# Altium schematic sheet ezfet.SchDoc
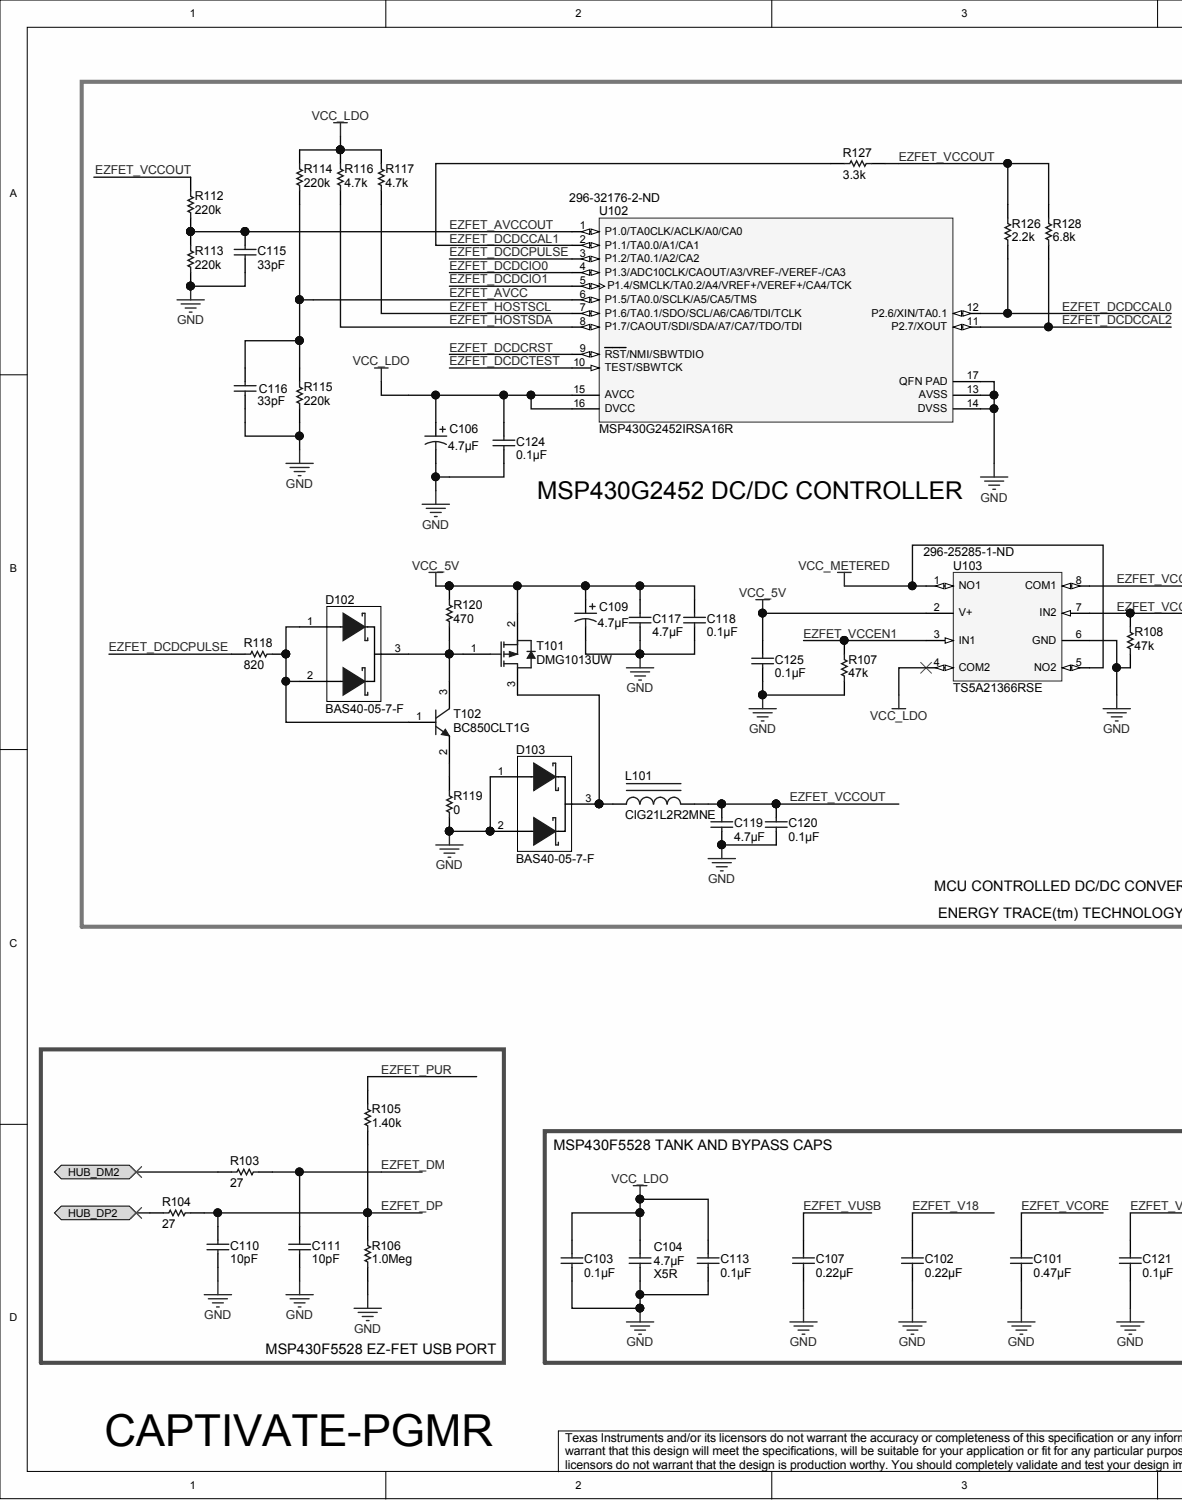
[diagram p0: ezfet.SchDoc, left]
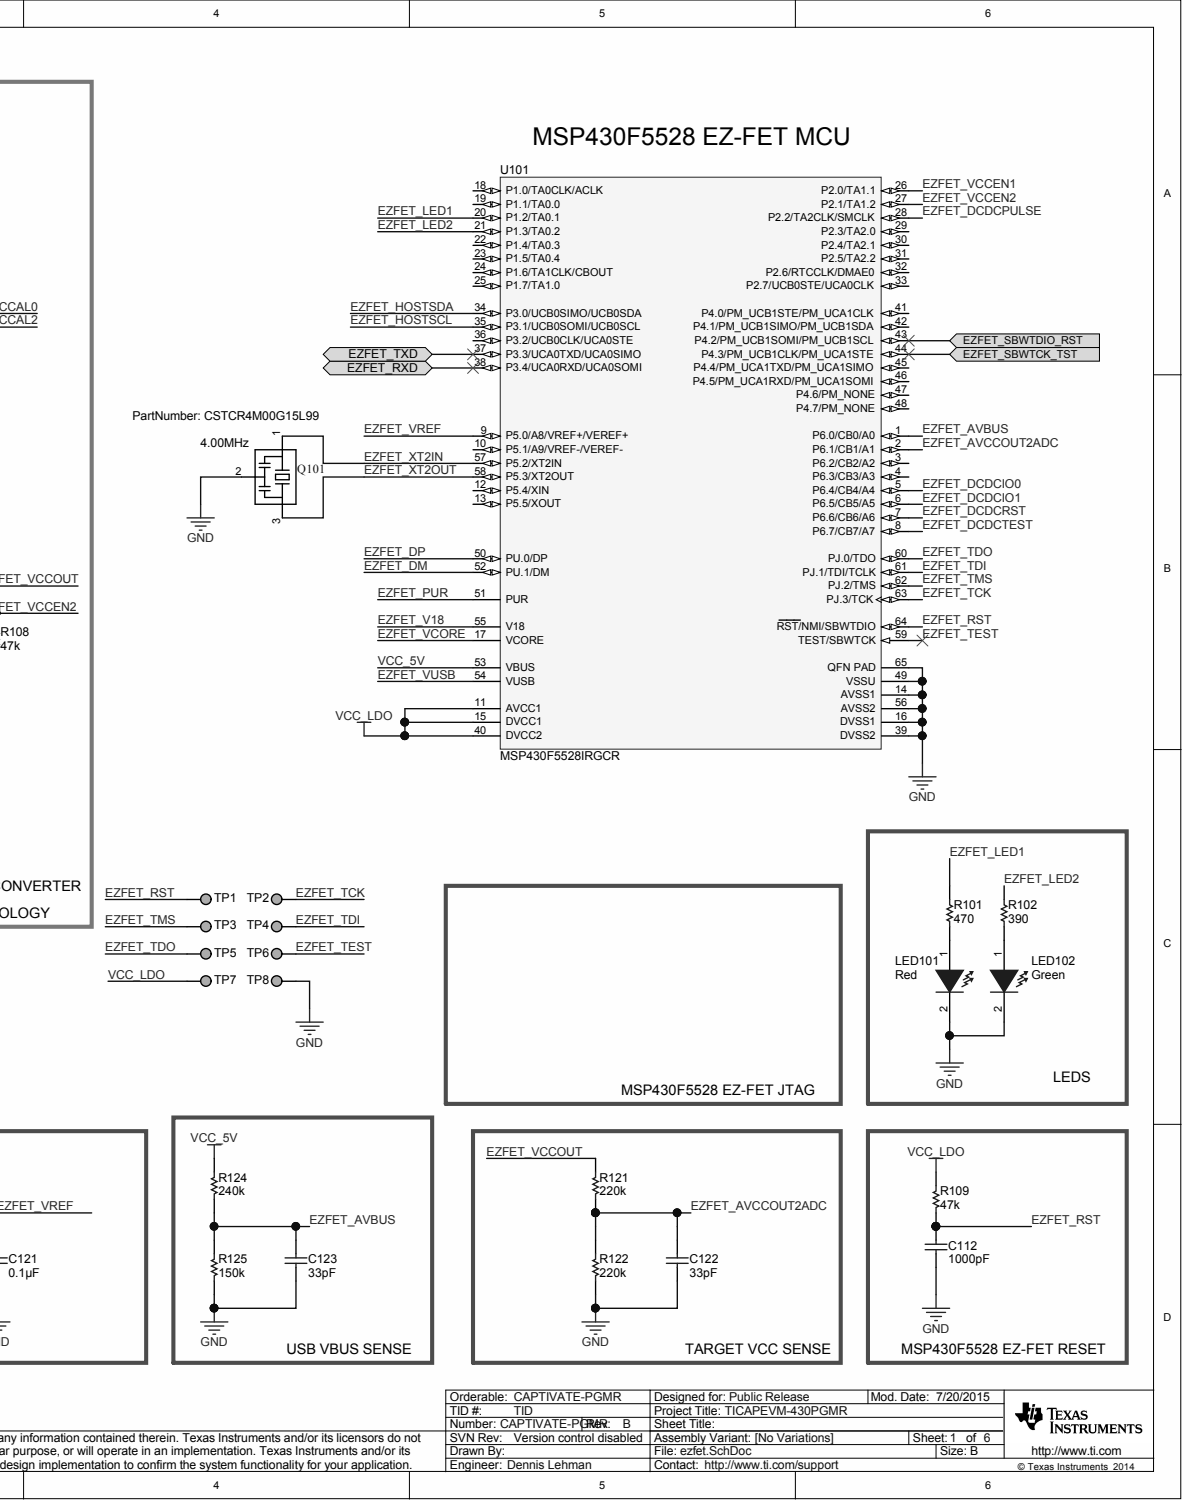
[diagram p1: ezfet.SchDoc, right]

## Parts
C101  ValueDisplayed: 0.47µF  [0402]  (hidden comment: GRM155R61A474KE15D)
C102  ValueDisplayed: 0.22µF  [0402]  (hidden comment: GRM155R61A224KE19D)
C103  ValueDisplayed: 0.1µF  [0402]  (hidden comment: C1005X5R1A104K)
C104  TempCo: X5R  ValueDisplayed: 4.7µF  [0603]  (hidden comment: CGB3B1X5R1A475K055AC)
C106  ValueDisplayed: 4.7µF  [3216-18]  (hidden comment: 293D475X9010A2TE3)
C107  ValueDisplayed: 0.22µF  [0402]  (hidden comment: GRM155R61A224KE19D)
C109  ValueDisplayed: 4.7µF  [3216-18]  (hidden comment: 293D475X9010A2TE3)
C110  value 10pF  [0402]  (hidden comment: 500R07S100JV4T)
C111  value 10pF  [0402]  (hidden comment: 500R07S100JV4T)
C112  value 1000pF  [0402]  (hidden comment: C1005X7R1H102K)
C113  ValueDisplayed: 0.1µF  [0402]  (hidden comment: C1005X5R1A104K)
C115  value 33pF  [0402]  (hidden comment: GRM1555C1H330JA01D)
C116  value 33pF  [0402]  (hidden comment: GRM1555C1H330JA01D)
C117  ValueDisplayed: 4.7µF  [0603]  (hidden comment: 0603ZD475KAT2A)
C118  ValueDisplayed: 0.1µF  [0402]  (hidden comment: C1005X5R1A104K)
C119  ValueDisplayed: 4.7µF  [0603]  (hidden comment: 0603ZD475KAT2A)
C120  ValueDisplayed: 0.1µF  [0402]  (hidden comment: C1005X5R1A104K)
C121  ValueDisplayed: 0.1µF  [0402]  (hidden comment: C1005X5R1A104K)
C122  value 33pF  [0402]  (hidden comment: GRM1555C1H330JA01D)
C123  value 33pF  [0402]  (hidden comment: GRM1555C1H330JA01D)
C124  ValueDisplayed: 0.1µF  [0402]  (hidden comment: C1005X5R1A104K)
C125  ValueDisplayed: 0.1µF  [0402]  (hidden comment: C1005X5R1A104K)
D102  BAS40-05-7-F  [SOT-23]
D103  BAS40-05-7-F  [SOT-23]
L101  CIG21L2R2MNE  [0805_HV]
LED101  value Red  [LED_LTST-C190_Red]  (hidden comment: LTST-C190CKT)
LED102  value Green  [LED_LTST-C190]  (hidden comment: LTST-C190GKT)
Q101  PartNumber: CSTCR4M00G15L99  Value Displayed: 4.00MHz  [CSTCR CERAMIC RESONATOR - MURATA]  (hidden comment: MURATA-FILTER_CSTCR4M00G15)
R101  value 470  [0402]  (hidden comment: CRCW0402470RJNED)
R102  value 390  [0402]  (hidden comment: CRCW0402390RJNED)
R103  value 27  [0402]  (hidden comment: CRCW040227R0JNED)
R104  value 27  [0402]  (hidden comment: CRCW040227R0JNED)
R105  value 1.40k  [0402]  (hidden comment: CRCW04021K40FKED)
R106  value 1.0Meg  [0402]  (hidden comment: CRCW04021M00JNED)
R107  value 47k  [0402]  (hidden comment: CRCW040247K0JNED)
R108  value 47k  [0402]  (hidden comment: CRCW040247K0JNED)
R109  value 47k  [0402]  (hidden comment: CRCW040247K0JNED)
R112  value 220k  [0402]  (hidden comment: CRCW0402220KJNED)
R113  value 220k  [0402]  (hidden comment: CRCW0402220KJNED)
R114  value 220k  [0402]  (hidden comment: CRCW0402220KJNED)
R115  value 220k  [0402]  (hidden comment: CRCW0402220KJNED)
R116  value 4.7k  [0402]  (hidden comment: CRCW04024K70JNED)
R117  value 4.7k  [0402]  (hidden comment: CRCW04024K70JNED)
R118  value 820  [0402]  (hidden comment: CRCW0402820RJNED)
R119  value 0  [0402]  (hidden comment: CRCW04020000Z0ED)
R120  value 470  [0402]  (hidden comment: CRCW0402470RJNED)
R121  value 220k  [0402]  (hidden comment: CRCW0402220KJNED)
R122  value 220k  [0402]  (hidden comment: CRCW0402220KJNED)
R124  value 240k  [0402]  (hidden comment: CRCW0402240KJNED)
R125  value 150k  [0402]  (hidden comment: CRCW0402150KFKED)
R126  value 2.2k  [0402]  (hidden comment: CRCW04022K20JNED)
R127  value 3.3k  [0402]  (hidden comment: CRCW04023K30JNED)
R128  value 6.8k  [0402]  (hidden comment: CRCW04026K80JNED)
T101  DMG1013UW  [SOT-323]
T102  BC850CLT1G  [SOT-23]
TP1  TESTPOINT  [TP_VIA_060RND040]  (value not drawn)  (no BOM)
TP2  TESTPOINT  [TP_VIA_060RND040]  (value not drawn)  (no BOM)
TP3  TESTPOINT  [TP_VIA_060RND040]  (value not drawn)  (no BOM)
TP4  TESTPOINT  [TP_VIA_060RND040]  (value not drawn)  (no BOM)
TP5  TESTPOINT  [TP_VIA_060RND040]  (value not drawn)  (no BOM)
TP6  TESTPOINT  [TP_VIA_060RND040]  (value not drawn)  (no BOM)
TP7  TESTPOINT  [TP_VIA_060RND040]  (value not drawn)  (no BOM)
TP8  TESTPOINT  [TP_VIA_060RND040]  (value not drawn)  (no BOM)
U101  MSP430F5528IRGCR  [RGC0064B]
U102  MSP430G2452IRSA16R  Supplier Part Number 1: 296-32176-2-ND  [RSA0016B]
U103  TS5A21366RSE  Supplier Part Number 1: 296-25285-1-ND  [RSE0008A]

## Ports
EZFET_RXD, EZFET_SBWTCK_TST, EZFET_SBWTDIO_RST, EZFET_TXD, HUB_DM2, HUB_DP2

## Nets
GND: C101.2 C102.2 C103.2 C104.2 C106.2 C107.2 C109.2 C110.2 C111.2 C112.2 C113.2 C115.2 C116.2 C117.2 C118.2 C119.2 C120.2 C121.2 C122.2 C123.2 C124.2 C125.2 D103.1 D103.2 LED101.2 LED102.2 Q101.2 R106.2 R107.1 R108.1 R113.1 R115.1 R119.2 R122.1 R125.1 TP8.1 U101.14(AVSS1) U101.16(DVSS1) U101.39(DVSS2) U101.49(VSSU) U101.56(AVSS2) U101.65(QFN_PAD) U102.13(AVSS) U102.14(DVSS) U102.17(QFN_PAD) U103.6(GND)
VCC_METERED: U103.1(NO1) U103.5(NO2)
EZFET_AVBUS: C123.1 R124.1 R125.2 U101.1(P6.0/CB0/A0)
EZFET_AVCC: C116.1 R114.1 R115.2 U102.6(P1.5/TA0.0/SCLK/A5/CA5/TMS)
EZFET_AVCCOUT: C115.1 R112.1 R113.2 U102.1(P1.0/TA0CLK/ACLK/A0/CA0)
EZFET_AVCCOUT2ADC: C122.1 R121.1 R122.2 U101.2(P6.1/CB1/A1)
EZFET_DCDCCAL0: R126.1 U102.12(P2.6/XIN/TA0.1)
EZFET_DCDCCAL1: R127.1 U102.2(P1.1/TA0.0/A1/CA1)
EZFET_DCDCCAL2: R128.1 U102.11(P2.7/XOUT)
EZFET_DCDCIO0: U101.5(P6.4/CB4/A4) U102.4(P1.3/ADC10CLK/CAOUT/A3/VREF-/VEREF-/CA3)
EZFET_DCDCIO1: U101.6(P6.5/CB5/A5) U102.5(P1.4/SMCLK/TA0.2/A4/VREF+/VEREF+/CA4/TCK)
EZFET_DCDCPULSE: R118.2 U101.28(P2.2/TA2CLK/SMCLK) U102.3(P1.2/TA0.1/A2/CA2)
EZFET_DCDCRST: U101.7(P6.6/CB6/A6) U102.9(RST/NMI/SBWTDIO)
EZFET_DCDCTEST: U101.8(P6.7/CB7/A7) U102.10(TEST/SBWTCK)
EZFET_DM: C111.1 R103.2 U101.52(PU.1/DM)
EZFET_DP: C110.1 R104.2 R105.2 R106.1 U101.50(PU.0/DP)
EZFET_HOSTSCL: R117.1 U101.35(P3.1/UCB0SOMI/UCB0SCL) U102.7(P1.6/TA0.1/SDO/SCL/A6/CA6/TDI/TCLK)
EZFET_HOSTSDA: R116.1 U101.34(P3.0/UCB0SIMO/UCB0SDA) U102.8(P1.7/CAOUT/SDI/SDA/A7/CA7/TDO/TDI)
EZFET_LED1: R101.2 U101.20(P1.2/TA0.1)
EZFET_LED2: R102.2 U101.21(P1.3/TA0.2)
EZFET_PUR: R105.1 U101.51(PUR)
EZFET_RST: C112.1 R109.1 TP1.1 U101.64(RST/NMI/SBWTDIO)
EZFET_RXD: U101.38(P3.4/UCA0RXD/UCA0SOMI) ; port EZFET_RXD
EZFET_SBWTCK_TST: U101.44(P4.3/PM_UCB1CLK/PM_UCA1STE) ; port EZFET_SBWTCK_TST
EZFET_SBWTDIO_RST: U101.43(P4.2/PM_UCB1SOMI/PM_UCB1SCL) ; port EZFET_SBWTDIO_RST
EZFET_TCK: TP2.1 U101.63(PJ.3/TCK)
EZFET_TDI: TP4.1 U101.61(PJ.1/TDI/TCLK)
EZFET_TDO: TP5.1 U101.60(PJ.0/TDO)
EZFET_TEST: TP6.1 U101.59(TEST/SBWTCK)
EZFET_TMS: TP3.1 U101.62(PJ.2/TMS)
EZFET_TXD: U101.37(P3.3/UCA0TXD/UCA0SIMO) ; port EZFET_TXD
EZFET_V18: C102.1 U101.55(V18)
EZFET_VCCEN1: R107.2 U101.26(P2.0/TA1.1) U103.3(IN1)
EZFET_VCCEN2: R108.2 U101.27(P2.1/TA1.2) U103.7(IN2)
EZFET_VCCOUT: C119.1 C120.1 L101.2 R112.2 R121.2 R126.2 R127.2 R128.2 U103.8(COM1)
EZFET_VCORE: C101.1 U101.17(VCORE)
EZFET_VREF: C121.1 U101.9(P5.0/A8/VREF+/VEREF+)
EZFET_VUSB: C107.1 U101.54(VUSB)
EZFET_XT2IN: Q101.1 U101.57(P5.2/XT2IN)
EZFET_XT2OUT: Q101.3 U101.58(P5.3/XT2OUT)
HUB_DM2: R103.1 ; port HUB_DM2
HUB_DP2: R104.1 ; port HUB_DP2
VCC_5V: C109.1 C117.1 C118.1 C125.1 R120.1 R124.2 T101.2 U101.53(VBUS) U103.2(V+)
VCC_LDO: C103.1 C104.1 C106.1 C113.1 C124.1 R109.2 R114.2 R116.2 R117.2 TP7.1 U101.11(AVCC1) U101.15(DVCC1) U101.40(DVCC2) U102.15(AVCC) U102.16(DVCC) U103.4(COM2)
NetD102_1: D102.1 D102.2 R118.1 T102.1
NetD102_3: D102.3 R120.2 T101.1 T102.3
NetD103_3: D103.3 L101.1 T101.3
NetLED101_1: LED101.1 R101.1
NetLED102_1: LED102.1 R102.1
NetR119_1: R119.1 T102.2

Not connected: U101 3(P6.2/CB2/A2) 4(P6.3/CB3/A3) 10(P5.1/A9/VREF-/VEREF-) 12(P5.4/XIN) 13(P5.5/XOUT) 18(P1.0/TA0CLK/ACLK) 19(P1.1/TA0.0) 22(P1.4/TA0.3) 23(P1.5/TA0.4) 24(P1.6/TA1CLK/CBOUT) 25(P1.7/TA1.0) 29(P2.3/TA2.0) 30(P2.4/TA2.1) 31(P2.5/TA2.2) 32(P2.6/RTCCLK/DMAE0) 33(P2.7/UCB0STE/UCA0CLK) 36(P3.2/UCB0CLK/UCA0STE) 41(P4.0/PM_UCB1STE/PM_UCA1CLK) 42(P4.1/PM_UCB1SIMO/PM_UCB1SDA) 45(P4.4/PM_UCA1TXD/PM_UCA1SIMO) 46(P4.5/PM_UCA1RXD/PM_UCA1SOMI) 47(P4.6/PM_NONE) 48(P4.7/PM_NONE)

## Library data of the parts
C101 = GRM155R61A474KE15D: CAP, CERM, 0.47uF, 10V, +/-10%, X5R, 0402; Manufacturer: MuRata; Cap_F: 0.00000047; CompType: Capacitor; Polarized: N; TaMax_C: 85; TaMin_C: -55; Tolerance_%: 10; U/M: EA; Value1: Unknown; Cap_Case: 0402; Component Kind: Standard; ComponentLink1Description: Datasheet link; ComponentLink1URL: http://search.murata.co.jp/Ceramy/PnsearchViewAction.do?HnOFG=ON&HnNFG=ON&SEL_CNT=50&sLang=en&sKey=GRM155R61A474KE15D&x=9&y=9&search=startwith; LatestRevisionDate: 2013-09-06; Library Name: Capacitor_Ceramic.IntLib; MountType: SMT; PackageReference: 0402; PartNumber: GRM155R61A474KE15D; Published: 2008-06-19; RoHS: Y; Signal Integrity: Cap; Simulation: Cap; Supplier 1: Digi-Key; Supplier 2: Mouser; Supplier 3: Farnell; Supplier Part Number 1: 490-3264-1-ND; Supplier Part Number 2: 81-GRM155R61A474KE5D; Supplier Part Number 3: 1828863; SymbolVersion: 2008-07-09; Technology: Ceramic; TempCo: X5R; Value: 0.47uF; Value2: 10V; VDC_V: 10; Publisher: Texas Instruments
C102 C107 = GRM155R61A224KE19D: CAP, CERM, 0.22uF, 10V, +/-10%, X5R, 0402; Manufacturer: MuRata; Cap_F: 0.00000022; CompType: Capacitor; Polarized: N; TaMax_C: 85; TaMin_C: -55; Tolerance_%: 10; U/M: EA; Value1: Unknown; Cap_Case: 0402; Component Kind: Standard; ComponentLink1Description: Datasheet link; ComponentLink1URL: http://search.murata.co.jp/Ceramy/PnsearchViewAction.do?HnOFG=ON&HnNFG=ON&SEL_CNT=50&sLang=en&sKey=GRM155R61A224KE19D&x=9&y=9&search=startwith; LatestRevisionDate: 2013-09-06; Library Name: Capacitor_Ceramic.IntLib; MountType: SMT; PackageReference: 0402; PartNumber: GRM155R61A224KE19D; Published: 2008-06-19; RoHS: Y; Signal Integrity: Cap; Simulation: Cap; Supplier 1: Digi-Key; Supplier 2: Mouser; Supplier 3: Farnell; Supplier Part Number 1: 490-3910-1-ND; Supplier Part Number 2: 81-GRM155R61A224KE19; Supplier Part Number 3: 8819718; SymbolVersion: 2008-07-09; Technology: Ceramic; TempCo: X5R; Value: 0.22uF; Value2: 10V; VDC_V: 10; Publisher: Texas Instruments
C103 C113 C118 C120 C121 C125 = C1005X5R1A104K: CAP, CERM, 0.1uF, 10V, +/-10%, X5R, 0402; Manufacturer: TDK; Cap_F: 0.0000001; CompType: Capacitor; ESR_Ohm: 0.0186; Polarized: N; TaMax_C: 85; TaMin_C: -55; Tolerance_%: 10; U/M: EA; Value1: 0.0186 ohm; Cap_Case: 0402; Component Kind: Standard; ComponentLink1Description: Datasheet link; ComponentLink1URL: http://www.tdk.co.jp/tefe02/e412_c.pdf; LatestRevisionDate: 2013-09-06; Library Name: Capacitor_Ceramic.IntLib; MountType: SMT; PackageReference: 0402; PartNumber: C1005X5R1A104K; Published: 2008-06-19; RoHS: Y; Signal Integrity: Cap; Simulation: Cap; Supplier 1: Digi-Key; Supplier 2: Farnell; Supplier Part Number 1: 445-1265-1-ND; Supplier Part Number 2: 1844111; SymbolVersion: 2008-07-09; Technology: Ceramic; TempCo: X5R; Value: 0.1uF; Value2: 10V; VDC_V: 10; Publisher: Texas Instruments
C104 = CGB3B1X5R1A475K055AC: CAP, CERM, 4.7 µF, 10 V, +/- 10%, X5R, 0603; Manufacturer: TDK; Cap_F: 0.0000047; CompType: Capacitor; Polarized: N; TaMax_C: 85; TaMin_C: -55; Tolerance_%: 10; U/M: EA; Value: 4.7uF; Value1: Unknown; Value2: 10V; Cap_Case: 0603; Component Kind: Standard; ComponentLink1Description: Datasheet link; ComponentLink1URL: http://www.tdk.com/pdf/mlcc_product_guide_A12.pdf; LatestRevisionDate: 2014-12-04; Library Name: Capacitor_Ceramic.IntLib; MountType: SMT; PackageReference: 0603; PartNumber: CGB3B1X5R1A475K055AC; Published: 2008-06-19; RoHS: Y; Signal Integrity: Cap; Simulation: Cap; Supplier 1: Digi-Key; Supplier Part Number 1: 445-7482-1-ND; SymbolVersion: 2008-07-09; Technology: Ceramic; VDC_V: 10V; Publisher: Texas Instruments
C106 C109 = 293D475X9010A2TE3: CAP, TA, 4.7 µF, 10 V, +/- 10%, 5 ohm, SMD; Manufacturer: Vishay-Sprague; Cap_Case: A; Cap_F: 0.0000047; CompType: Capacitor; ESR_ohm: 5; IRMS_A: 0.12; Polarized: Y; TaMax_C: 125; TaMin_C: -55; Tolerance_%: 10; U/M: EA; Value: 4.7uF; Value1: 5 ohm; Value2: 10V; Component Kind: Standard; ComponentLink1Description: Datasheet link; ComponentLink1URL: http://www.vishay.com/docs/40002/293d.pdf; LatestRevisionDate: 2014-11-20; LatestRevisionNote: Updated supplier links; Library Name: Capacitor_Tantalum.IntLib; MountType: SMT; PackageReference: 3216-18; PartNumber: 293D475X9010A2TE3; RoHS: Y; Series: 293D; Signal Integrity: Cap; Simulation: Cap; Supplier 1: Digi-Key; Supplier 2: Mouser; Supplier 3: Farnell; Supplier Part Number 1: 718-1146-1-ND; Supplier Part Number 2: 74-293D475X9010A2TE3; Supplier Part Number 3: 197397; SymbolVersion: 2008-07-09; Technology: TA; VDC_V: 10; Publisher: Texas Instruments
C110 C111 = 500R07S100JV4T: CAP, CERM, 10pF, 50V, +/-5%, C0G/NP0, 0402; Manufacturer: Johanson Technology; Cap_F: 0.00000000001; CompType: Capacitor; Polarized: N; TaMax_C: 125; TaMin_C: -55; Tolerance_%: 5; U/M: EA; Value1: Unknown; VDC_V: 50; Cap_Case: 0402; Component Kind: Standard; ComponentLink1Description: Datasheet link; ComponentLink1URL: http://johansontechnology.com/images/stories/rfcaps/mlhqcaps/JTI_MLCC_HighQ_2011_14.pdf; LatestRevisionDate: 2013-09-06; Library Name: Capacitor_Ceramic.IntLib; MountType: SMT; PackageReference: 0402; PartNumber: 500R07S100JV4T; Published: 2008-06-19; RoHS: Y; Signal Integrity: Cap; Simulation: Cap; Supplier 1: Digi-Key; Supplier 2: Farnell; Supplier 3: Newark; Supplier Part Number 1: 712-1253-1-ND; Supplier Part Number 2: 1885333; Supplier Part Number 3: 97M6183; SymbolVersion: 2008-07-09; Technology: Ceramic; TempCo: C0G/NP0; Value2: 50V; ValueDisplayed: 10pF; Publisher: Texas Instruments
C112 = C1005X7R1H102K: CAP, CERM, 1000pF, 50V, +/-10%, X7R, 0402; Manufacturer: TDK; Cap_F: 0.000000001; CompType: Capacitor; ESR_Ohm: 0.2381; Polarized: N; TaMax_C: 125; TaMin_C: -55; Tolerance_%: 10; U/M: EA; Value1: 0.2381 ohm; VDC_V: 50; Cap_Case: 0402; Component Kind: Standard; ComponentLink1Description: Datasheet link; ComponentLink1URL: http://www.tdk.co.jp/tefe02/e412_c.pdf; LatestRevisionDate: 2013-09-06; Library Name: Capacitor_Ceramic.IntLib; MountType: SMT; PackageReference: 0402; PartNumber: C1005X7R1H102K; Published: 2008-06-19; RoHS: Y; Signal Integrity: Cap; Simulation: Cap; Supplier 1: Digi-Key; Supplier 2: Farnell; Supplier Part Number 1: 445-1256-1-ND; Supplier Part Number 2: 1907278; SymbolVersion: 2008-07-09; Technology: Ceramic; TempCo: X7R; Value2: 50V; ValueDisplayed: 1000pF; Publisher: Texas Instruments
C115 C116 C122 C123 = GRM1555C1H330JA01D: CAP, CERM, 33pF, 50V, +/-5%, C0G/NP0, 0402; Manufacturer: MuRata; Cap_F: 0.000000000033; CompType: Capacitor; Polarized: N; TaMax_C: 125; TaMin_C: -55; Tolerance_%: 5; U/M: EA; Value1: Unknown; VDC_V: 50; Cap_Case: 0402; Component Kind: Standard; ComponentLink1Description: Datasheet link; ComponentLink1URL: http://search.murata.co.jp/Ceramy/PnsearchViewAction.do?HnOFG=ON&HnNFG=ON&SEL_CNT=50&sLang=en&sKey=GRM1555C1H330JA01D&x=9&y=9&search=startwith; LatestRevisionDate: 2013-09-06; Library Name: Capacitor_Ceramic.IntLib; MountType: SMT; PackageReference: 0402; PartNumber: GRM1555C1H330JA01D; Published: 2008-06-19; RoHS: Y; Signal Integrity: Cap; Simulation: Cap; Supplier 1: Mouser; Supplier Part Number 1: 81-GRM1555C1H330JA1D; SymbolVersion: 2008-07-09; Technology: Ceramic; TempCo: C0G/NP0; Value2: 50V; ValueDisplayed: 33pF; Publisher: Texas Instruments
C117 C119 = 0603ZD475KAT2A: CAP, CERM, 4.7 µF, 10 V, +/- 10%, X5R, 0603; Manufacturer: AVX; Cap_F: 0.0000047; CompType: Capacitor; Polarized: N; TaMax_C: 85; TaMin_C: -55; Tolerance_%: 10; U/M: EA; Value: 4.7uF; Value1: Unknown; Value2: 10V; Cap_Case: 0603; Component Kind: Standard; ComponentLink1Description: Datasheet link; ComponentLink1URL: http://www.avx.com/docs/Catalogs/cx5r.pdf; LatestRevisionDate: 2014-12-10; Library Name: Capacitor_Ceramic.IntLib; MountType: SMT; PackageReference: 0603; PartNumber: 0603ZD475KAT2A; Published: 2008-06-19; RoHS: Y; Signal Integrity: Cap; Simulation: Cap; Supplier 1: Digi-Key; Supplier 2: Mouser; Supplier 3: Farnell; Supplier Part Number 1: 478-7943-1-ND; Supplier Part Number 2: 581-0603ZD475KAT2A; Supplier Part Number 3: 1833806; SymbolVersion: 2008-07-09; Technology: Ceramic; TempCo: X5R; VDC_V: 10; Publisher: Texas Instruments
C124 = C1005X5R1A104K: CAP, CERM, 0.1 µF, 10 V, +/- 10%, X5R, 0402; Manufacturer: TDK; Cap_F: 0.0000001; CompType: Capacitor; ESR_ohm: 0.0186; Polarized: N; TaMax_C: 85; TaMin_C: -55; Tolerance_%: 10; U/M: EA; Value: 0.1uF; Value1: 0.0186 ohm; Value2: 10V; Cap_Case: 0402; Component Kind: Standard; ComponentLink1Description: Datasheet link; ComponentLink1URL: http://www.tdk.co.jp/tefe02/e412_c.pdf; LatestRevisionDate: 2014-12-10; Library Name: Capacitor_Ceramic.IntLib; MountType: SMT; PackageReference: 0402; PartNumber: C1005X5R1A104K; Published: 2008-06-19; RoHS: Y; Signal Integrity: Cap; Simulation: Cap; Supplier 1: Digi-Key; Supplier 2: Farnell; Supplier Part Number 1: 445-1265-1-ND; Supplier Part Number 2: 1844111; SymbolVersion: 2008-07-09; Technology: Ceramic; TempCo: X5R; VDC_V: 10; Publisher: Texas Instruments
D102 D103 = BAS40-05-7-F: Diode, Schottky, 40V, 0.2A, SOT-23; Manufacturer: Diodes Inc.; Io_A: 0.2; SymbolCreator: a0412235; U/M: EA; Value2: 0.9V; Vf_V: 0.9; Vr_V: 40; Component Kind: Standard; ComponentLink1Description: Datasheet link; ComponentLink1URL: http://www.diodes.com/datasheets/ds11006.pdf; CompType: Diode; LatestRevisionDate: 2014-05-28; Library Name: Diode.IntLib; MountType: SMT; PackageReference: SOT-23; PartNumber: BAS40-05-7-F; Published: 2008-06-26; RoHS: Y; Simulation: DI_BAS40-05; Supplier 1: Digi-Key; Supplier 2: Mouser; Supplier 3: Farnell; Supplier Part Number 1: BAS40-05DICT-ND; Supplier Part Number 2: 621-BAS40-05-F; Supplier Part Number 3: 1843739; SymbolVersion: 2013-03-27; Type: Schottky; Value: 40V; Value1: 0.2A; Publisher: Texas Instruments
L101 = CIG21L2R2MNE: Inductor, Shielded, Composite, 2.2uH, 0.95A, 0.16 ohm, SMD; Manufacturer: Samsung; DCR_ohm: 0.16; Imax_A: 0.95; Ind_H: 2.2E-6; Irms: 0.95A @ 40C rise; ValueDisplayed: 2.2µH; Component Kind: Standard; ComponentLink1Description: Datasheet link; ComponentLink1URL: http://www.samsungsem.com/servlet/FileDownload?type=spec&file=CIG21L2R2MNE.pdf; CompType: Inductor; LatestRevisionDate: 2014-05-23; Library Name: Inductor_Other.IntLib; Material: Composite; MountType: SMT; PackageReference: 0805; PartNumber: CIG21L2R2MNE; Published: 2008-06-26; RoHS: Y; Signal Integrity: Inductor; Simulation: Inductor; Supplier 1: Digi-Key; Supplier Part Number 1: 1276-6202-1-ND; SymbolVersion: 2008-07-23; Technology: Shielded; Value: 2.2uH; Value1: 0.16 ohm; Value2: 0.95A; Publisher: Texas Instruments
LED101 = LTST-C190CKT: LED, Red, SMD; Manufacturer: Lite-On; Io_A: 0.02; Power_TotalModule: 0.0625; Tjmax_C: 85; U/M: EA; Value1: 0.0625W; Value2: 2V; Vf_V: 2; Color: red; Component Kind: Standard; ComponentLink1Description: Datasheet link; ComponentLink1URL: http://optoelectronics.liteon.com/en-us/api/DwonloadFileHandler.ashx?txtSpecNo=DS-22-98-0009&txtPartNo=LTST-C190CKT; CompType: LED; LatestRevisionDate: 2013-09-07; Library Name: LED.IntLib; MountType: SMT; PackageReference: Red LED, 1.6x0.8x0.8mm; PartNumber: LTST-C190CKT; Published: 2008-07-11; RoHS: Y; Signal Integrity: Diode; Supplier 1: Digi-Key; Supplier 2: Mouser; Supplier Part Number 1: 160-1181-1-ND; Supplier Part Number 2: 859-LTST-C190CKT; SymbolVersion: 2008-12-08; Type: ?; Publisher: Texas Instruments
LED102 = LTST-C190GKT: LED, Green, SMD; Manufacturer: Lite-On; Io_A: 0.02; Power_TotalModule: 0.1; U/M: EA; Value1: 0.1W; Value2: 2.1V; Vf_V: 2.1; Color: green; Component Kind: Standard; ComponentLink1Description: Datasheet link; ComponentLink1URL: https://sps06.itg.ti.com/sites/KMS/crossdivteams/altium/docs/ComponentDatasheets/LTST-C190GKT.pdf; CompType: LED; LatestRevisionDate: 2013-09-07; Library Name: LED.IntLib; MountType: SMT; PackageReference: 1.6x0.8x0.8mm; PartNumber: LTST-C190GKT; Published: 2008-07-11; RoHS: Y; Signal Integrity: Diode; Supplier 1: Digi-Key; Supplier 2: Mouser; Supplier Part Number 1: 160-1183-1-ND; Supplier Part Number 2: 859-LTST-C190GKT; SymbolVersion: 2008-12-08; Type: ?; Publisher: Texas Instruments
Q101 = MURATA-FILTER_CSTCR4M00G15: 4.00MHz resonator; Component Kind: Standard; Supplier 1: Murata; Supplier Part Number 1: CSTCR4M00G15L99; Library Name: Captivate Library.IntLib
R101 = CRCW0402470RJNED: RES, 470 ohm, 5%, 0.063W, 0402; Manufacturer: Vishay-Dale; CompType: Resistor; EIA_Code: E24; Power_W: 0.063; TempCo: 200 ppm/C; Tolerance_%: 5; U/M: EA; Value_Code: 470R; VDC_V: 50; Component Kind: Standard; ComponentLink1Description: Datasheet link; ComponentLink1URL: http://www.vishay.com/docs/20035/dcrcwe3.pdf; LatestRevisionDate: 2013-01-08; Library Name: Resistor_0402.IntLib; MountType: SMT; PackageReference: 0402; PartNumber: CRCW0402470RJNED; Published: 2008-06-26; Res_ohm: 470; RoHS: Y; Signal Integrity: Resistor; Simulation: Resistor; Supplier 1: Digi-Key; Supplier 2: Mouser; Supplier 3: Farnell; Supplier Part Number 1: 541-470JCT-ND; Supplier Part Number 2: 71-CRCW0402J-470-E3; Supplier Part Number 3: 1537425; SymbolVersion: 2009-05-29; Technology: Thick Film; Value1: 5%; Value2: 0.063W; Publisher: Texas Instruments
R102 = CRCW0402390RJNED: RES, 390 ohm, 5%, 0.063W, 0402; Manufacturer: Vishay-Dale; CompType: Resistor; EIA_Code: E24; Power_W: 0.063; TempCo: 200 ppm/C; Tolerance_%: 5; U/M: EA; Value_Code: 390R; VDC_V: 50; Component Kind: Standard; ComponentLink1Description: Datasheet link; ComponentLink1URL: http://www.vishay.com/docs/20035/dcrcwe3.pdf; LatestRevisionDate: 2013-01-08; Library Name: Resistor_0402.IntLib; MountType: SMT; PackageReference: 0402; PartNumber: CRCW0402390RJNED; Published: 2008-06-26; Res_ohm: 390; RoHS: Y; Signal Integrity: Resistor; Simulation: Resistor; Supplier 1: Digi-Key; Supplier 2: Mouser; Supplier 3: Farnell; Supplier Part Number 1: 541-390JCT-ND; Supplier Part Number 2: 71-CRCW0402J-390-E3; Supplier Part Number 3: 1514701; SymbolVersion: 2009-05-29; Technology: Thick Film; Value1: 5%; Value2: 0.063W; Publisher: Texas Instruments
R103 R104 = CRCW040227R0JNED: RES, 27 ohm, 5%, 0.063W, 0402; Manufacturer: Vishay-Dale; CompType: Resistor; EIA_Code: E24; Power_W: 0.063; TempCo: 200 ppm/C; Tolerance_%: 5; U/M: EA; Value_Code: 27R0; VDC_V: 50; Component Kind: Standard; ComponentLink1Description: Datasheet link; ComponentLink1URL: http://www.vishay.com/docs/20035/dcrcwe3.pdf; LatestRevisionDate: 2013-01-08; Library Name: Resistor_0402.IntLib; MountType: SMT; PackageReference: 0402; PartNumber: CRCW040227R0JNED; Published: 2008-06-26; Res_ohm: 27; RoHS: Y; Signal Integrity: Resistor; Simulation: Resistor; Supplier 1: Digi-Key; Supplier 2: Mouser; Supplier 3: Farnell; Supplier Part Number 1: 541-27JCT-ND; Supplier Part Number 2: 71-CRCW0402J-27-E3; Supplier Part Number 3: 1514690; SymbolVersion: 2009-05-29; Technology: Thick Film; Value1: 5%; Value2: 0.063W; Publisher: Texas Instruments
R105 = CRCW04021K40FKED: RES, 1.40 k, 1%, 0.063 W, 0402; Manufacturer: Vishay-Dale; CompType: Resistor; EIA_Code: E96; Power_W: 0.063; Res_ohm: 1400; TempCo: 100 ppm/C; Tolerance_%: 1; U/M: EA; Value2: 0.063W; Value_Code: 1k40; VDC_V: 50; Component Kind: Standard; ComponentLink1Description: Datasheet link; ComponentLink1URL: http://www.vishay.com/docs/20035/dcrcwe3.pdf; LatestRevisionDate: 2014-11-19; Library Name: Resistor_0402.IntLib; MountType: SMT; PackageReference: 0402; PartNumber: CRCW04021K40FKED; Published: 2008-06-26; RoHS: Y; Signal Integrity: Resistor; Simulation: Resistor; Supplier 1: Digi-Key; Supplier 2: Mouser; Supplier 3: Farnell; Supplier Part Number 1: 541-1.40KLCT-ND; Supplier Part Number 2: 71-CRCW0402-1.4K-E3; Supplier Part Number 3: 1469664; SymbolVersion: 2009-05-29; Technology: Thick Film; Value1: 1%; ValueDisplayed: 1.40k; Publisher: Texas Instruments
R106 = CRCW04021M00JNED: RES, 1.0Meg ohm, 5%, 0.063W, 0402; Manufacturer: Vishay-Dale; CompType: Resistor; EIA_Code: E24; Power_W: 0.063; Res_ohm: 1000000; TempCo: 200 ppm/C; Tolerance_%: 5; U/M: EA; Value_Code: 1M00; VDC_V: 50; Component Kind: Standard; ComponentLink1Description: Datasheet link; ComponentLink1URL: http://www.vishay.com/docs/20035/dcrcwe3.pdf; LatestRevisionDate: 2013-01-08; Library Name: Resistor_0402.IntLib; MountType: SMT; PackageReference: 0402; PartNumber: CRCW04021M00JNED; Published: 2008-06-26; RoHS: Y; Signal Integrity: Resistor; Simulation: Resistor; Supplier 1: Digi-Key; Supplier 2: Mouser; Supplier 3: Farnell; Supplier Part Number 1: 541-1.0MJCT-ND; Supplier Part Number 2: 71-CRCW0402J-1M-E3; Supplier Part Number 3: 1514661; SymbolVersion: 2009-05-29; Technology: Thick Film; Value1: 5%; Value2: 0.063W; Publisher: Texas Instruments
R107 R108 = CRCW040247K0JNED: RES, 47 k, 5%, 0.063 W, 0402; Manufacturer: Vishay-Dale; CompType: Resistor; EIA_Code: E24; Power_W: 0.063; Res_ohm: 47000; TempCo: 200 ppm/C; Tolerance_%: 5; U/M: EA; Value2: 0.063W; Value_Code: 47k0; VDC_V: 50; Component Kind: Standard; ComponentLink1Description: Datasheet link; ComponentLink1URL: http://www.vishay.com/docs/20035/dcrcwe3.pdf; LatestRevisionDate: 2014-11-19; Library Name: Resistor_0402.IntLib; MountType: SMT; PackageReference: 0402; PartNumber: CRCW040247K0JNED; Published: 2008-06-26; RoHS: Y; Signal Integrity: Resistor; Simulation: Resistor; Supplier 1: Digi-Key; Supplier 2: Mouser; Supplier 3: Farnell; Supplier Part Number 1: 541-47KJCT-ND; Supplier Part Number 2: 71-CRCW0402-J-47K-E3; Supplier Part Number 3: 1514553; SymbolVersion: 2009-05-29; Technology: Thick Film; Value1: 5%; ValueDisplayed: 47k; Publisher: Texas Instruments
R109 = CRCW040247K0JNED: RES, 47k ohm, 5%, 0.063W, 0402; Manufacturer: Vishay-Dale; CompType: Resistor; EIA_Code: E24; Power_W: 0.063; Res_ohm: 47000; TempCo: 200 ppm/C; Tolerance_%: 5; U/M: EA; Value_Code: 47k0; VDC_V: 50; Component Kind: Standard; ComponentLink1Description: Datasheet link; ComponentLink1URL: http://www.vishay.com/docs/20035/dcrcwe3.pdf; LatestRevisionDate: 2013-01-08; Library Name: Resistor_0402.IntLib; MountType: SMT; PackageReference: 0402; PartNumber: CRCW040247K0JNED; Published: 2008-06-26; RoHS: Y; Signal Integrity: Resistor; Simulation: Resistor; Supplier 1: Digi-Key; Supplier 2: Farnell; Supplier 3: Newark; Supplier Part Number 1: 541-47KJCT-ND; Supplier Part Number 2: 1514553; Supplier Part Number 3: 52K7099; SymbolVersion: 2009-05-29; Technology: Thick Film; Value1: 5%; Value2: 0.063W; Publisher: Texas Instruments
R112-R115 = CRCW0402220KJNED: RES, 220 k, 5%, 0.063 W, 0402; Manufacturer: Vishay-Dale; CompType: Resistor; EIA_Code: E24; Power_W: 0.063; Res_ohm: 220000; TempCo: 200 ppm/C; Tolerance_%: 5; U/M: EA; Value2: 0.063W; VDC_V: 50; Component Kind: Standard; ComponentLink1Description: Datasheet link; ComponentLink1URL: http://www.vishay.com/docs/20035/dcrcwe3.pdf; LatestRevisionDate: 2014-11-19; Library Name: Resistor_0402.IntLib; MountType: SMT; PackageReference: 0402; PartNumber: CRCW0402220KJNED; Published: 2008-06-26; RoHS: Y; Signal Integrity: Resistor; Simulation: Resistor; Supplier 1: Digi-Key; Supplier 2: Mouser; Supplier 3: Farnell; Supplier Part Number 1: 541-220KJCT-ND; Supplier Part Number 2: 71-CRCW0402J-220K-E3; Supplier Part Number 3: 1514686; SymbolVersion: 2009-05-29; Technology: Thick Film; Value1: 5%; Value_Code: 220k; ValueDisplayed: 220k; Publisher: Texas Instruments
R116 R117 = CRCW04024K70JNED: RES, 4.7 k, 5%, 0.063 W, 0402; Manufacturer: Vishay-Dale; CompType: Resistor; EIA_Code: E24; Power_W: 0.063; Res_ohm: 4700; TempCo: 200 ppm/C; Tolerance_%: 5; U/M: EA; Value2: 0.063W; Value_Code: 4k70; VDC_V: 50; Component Kind: Standard; ComponentLink1Description: Datasheet link; ComponentLink1URL: http://www.vishay.com/docs/20035/dcrcwe3.pdf; LatestRevisionDate: 2014-11-19; Library Name: Resistor_0402.IntLib; MountType: SMT; PackageReference: 0402; PartNumber: CRCW04024K70JNED; Published: 2008-06-26; RoHS: Y; Signal Integrity: Resistor; Simulation: Resistor; Supplier 1: Digi-Key; Supplier 2: Mouser; Supplier 3: Farnell; Supplier Part Number 1: 541-4.7KJCT-ND; Supplier Part Number 2: 71-CRCW0402J-4.7K-E3; Supplier Part Number 3: 1514704; SymbolVersion: 2009-05-29; Technology: Thick Film; Value1: 5%; ValueDisplayed: 4.7k; Publisher: Texas Instruments
R118 = CRCW0402820RJNED: RES, 820, 5%, 0.063 W, 0402; Manufacturer: Vishay-Dale; CompType: Resistor; EIA_Code: E24; Power_W: 0.063; TempCo: 200 ppm/C; Tolerance_%: 5; U/M: EA; Value2: 0.063W; Value_Code: 820R; VDC_V: 50; Component Kind: Standard; ComponentLink1Description: Datasheet link; ComponentLink1URL: http://www.vishay.com/docs/20035/dcrcwe3.pdf; LatestRevisionDate: 2014-11-19; Library Name: Resistor_0402.IntLib; MountType: SMT; PackageReference: 0402; PartNumber: CRCW0402820RJNED; Published: 2008-06-26; Res_ohm: 820; RoHS: Y; Signal Integrity: Resistor; Simulation: Resistor; Supplier 1: Digi-Key; Supplier 2: Mouser; Supplier 3: Farnell; Supplier Part Number 1: 541-820JCT-ND; Supplier Part Number 2: 71-CRCW0402J-820-E3; Supplier Part Number 3: 1570698; SymbolVersion: 2009-05-29; Technology: Thick Film; Value1: 5%; ValueDisplayed: 820; Publisher: Texas Instruments
R119 = CRCW04020000Z0ED: RES, 0, 5%, 0.063 W, 0402; Manufacturer: Vishay-Dale; CompType: Resistor; EIA_Code: E24; Power_W: 0.063; TempCo: 200 ppm/C; Tolerance_%: 5; U/M: EA; Value2: 0.063W; Value_Code: 0000; VDC_V: 50; Component Kind: Standard; ComponentLink1Description: Datasheet link; ComponentLink1URL: http://www.vishay.com/docs/20035/dcrcwe3.pdf; LatestRevisionDate: 2014-11-19; Library Name: Resistor_0402.IntLib; MountType: SMT; PackageReference: 0402; PartNumber: CRCW04020000Z0ED; Published: 2008-06-26; Res_ohm: 0; RoHS: Y; Signal Integrity: Resistor; Simulation: Resistor; Supplier 1: Digi-Key; Supplier 2: Mouser; Supplier 3: Farnell; Supplier Part Number 1: 541-0.0JCT-ND; Supplier Part Number 2: 71-CRCW0402-0-E3; Supplier Part Number 3: 1469661; SymbolVersion: 2009-05-29; Technology: Thick Film; Value1: 5%; ValueDisplayed: 0; Publisher: Texas Instruments
R120 = CRCW0402470RJNED: RES, 470, 5%, 0.063 W, 0402; Manufacturer: Vishay-Dale; CompType: Resistor; EIA_Code: E24; Power_W: 0.063; TempCo: 200 ppm/C; Tolerance_%: 5; U/M: EA; Value2: 0.063W; Value_Code: 470R; VDC_V: 50; Component Kind: Standard; ComponentLink1Description: Datasheet link; ComponentLink1URL: http://www.vishay.com/docs/20035/dcrcwe3.pdf; LatestRevisionDate: 2014-11-19; Library Name: Resistor_0402.IntLib; MountType: SMT; PackageReference: 0402; PartNumber: CRCW0402470RJNED; Published: 2008-06-26; Res_ohm: 470; RoHS: Y; Signal Integrity: Resistor; Simulation: Resistor; Supplier 1: Digi-Key; Supplier 2: Mouser; Supplier 3: Farnell; Supplier Part Number 1: 541-470JCT-ND; Supplier Part Number 2: 71-CRCW0402J-470-E3; Supplier Part Number 3: 1537425; SymbolVersion: 2009-05-29; Technology: Thick Film; Value1: 5%; ValueDisplayed: 470; Publisher: Texas Instruments
R121 R122 = CRCW0402220KJNED: RES, 220k ohm, 5%, 0.063W, 0402; Manufacturer: Vishay-Dale; CompType: Resistor; EIA_Code: E24; Power_W: 0.063; Res_ohm: 220000; TempCo: 200 ppm/C; Tolerance_%: 5; U/M: EA; VDC_V: 50; Component Kind: Standard; ComponentLink1Description: Datasheet link; ComponentLink1URL: http://www.vishay.com/docs/20035/dcrcwe3.pdf; LatestRevisionDate: 2013-01-08; Library Name: Resistor_0402.IntLib; MountType: SMT; PackageReference: 0402; PartNumber: CRCW0402220KJNED; Published: 2008-06-26; RoHS: Y; Signal Integrity: Resistor; Simulation: Resistor; Supplier 1: Digi-Key; Supplier 2: Farnell; Supplier 3: Newark; Supplier Part Number 1: 541-220KJCT-ND; Supplier Part Number 2: 1514686; Supplier Part Number 3: 59M6717; SymbolVersion: 2009-05-29; Technology: Thick Film; Value1: 5%; Value2: 0.063W; Value_Code: 220k; Publisher: Texas Instruments
R124 = CRCW0402240KJNED: RES, 240k ohm, 5%, 0.063W, 0402; Manufacturer: Vishay-Dale; CompType: Resistor; EIA_Code: E24; Power_W: 0.063; Res_ohm: 240000; TempCo: 200 ppm/C; Tolerance_%: 5; U/M: EA; VDC_V: 50; Component Kind: Standard; ComponentLink1Description: Datasheet link; ComponentLink1URL: http://www.vishay.com/docs/20035/dcrcwe3.pdf; LatestRevisionDate: 2013-01-08; Library Name: Resistor_0402.IntLib; MountType: SMT; PackageReference: 0402; PartNumber: CRCW0402240KJNED; Published: 2008-06-26; RoHS: Y; Signal Integrity: Resistor; Simulation: Resistor; Supplier 1: Digi-Key; Supplier Part Number 1: 541-240KJCT-ND; SymbolVersion: 2009-05-29; Technology: Thick Film; Value1: 5%; Value2: 0.063W; Value_Code: 240k; Publisher: Texas Instruments
R125 = CRCW0402150KFKED: RES, 150k ohm, 1%, 0.063W, 0402; Manufacturer: Vishay-Dale; CompType: Resistor; EIA_Code: E96; Power_W: 0.063; Res_ohm: 150000; TempCo: 100 ppm/C; Tolerance_%: 1; U/M: EA; VDC_V: 50; Component Kind: Standard; ComponentLink1Description: Datasheet link; ComponentLink1URL: http://www.vishay.com/docs/20035/dcrcwe3.pdf; LatestRevisionDate: 2013-01-08; Library Name: Resistor_0402.IntLib; MountType: SMT; PackageReference: 0402; PartNumber: CRCW0402150KFKED; Published: 2008-06-26; RoHS: Y; Signal Integrity: Resistor; Simulation: Resistor; Supplier 1: Digi-Key; Supplier 2: Mouser; Supplier 3: Farnell; Supplier Part Number 1: 541-150KLCT-ND; Supplier Part Number 2: 71-CRCW0402-150K-E3; Supplier Part Number 3: 1652753; SymbolVersion: 2009-05-29; Technology: Thick Film; Value1: 1%; Value2: 0.063W; Value_Code: 150k; Publisher: Texas Instruments
R126 = CRCW04022K20JNED: RES, 2.2 k, 5%, 0.063 W, 0402; Manufacturer: Vishay-Dale; CompType: Resistor; EIA_Code: E24; Power_W: 0.063; Res_ohm: 2200; TempCo: 200 ppm/C; Tolerance_%: 5; U/M: EA; Value2: 0.063W; Value_Code: 2k20; VDC_V: 50; Component Kind: Standard; ComponentLink1Description: Datasheet link; ComponentLink1URL: http://www.vishay.com/docs/20035/dcrcwe3.pdf; LatestRevisionDate: 2014-11-19; Library Name: Resistor_0402.IntLib; MountType: SMT; PackageReference: 0402; PartNumber: CRCW04022K20JNED; Published: 2008-06-26; RoHS: Y; Signal Integrity: Resistor; Simulation: Resistor; Supplier 1: Digi-Key; Supplier 2: Mouser; Supplier 3: Farnell; Supplier Part Number 1: 541-2.2KJCT-ND; Supplier Part Number 2: 71-CRCW0402J-2.2K-E3; Supplier Part Number 3: 1514678; SymbolVersion: 2009-05-29; Technology: Thick Film; Value1: 5%; ValueDisplayed: 2.2k; Publisher: Texas Instruments
R127 = CRCW04023K30JNED: RES, 3.3 k, 5%, 0.063 W, 0402; Manufacturer: Vishay-Dale; CompType: Resistor; EIA_Code: E24; Power_W: 0.063; Res_ohm: 3300; TempCo: 200 ppm/C; Tolerance_%: 5; U/M: EA; Value2: 0.063W; Value_Code: 3k30; VDC_V: 50; Component Kind: Standard; ComponentLink1Description: Datasheet link; ComponentLink1URL: http://www.vishay.com/docs/20035/dcrcwe3.pdf; LatestRevisionDate: 2014-11-19; Library Name: Resistor_0402.IntLib; MountType: SMT; PackageReference: 0402; PartNumber: CRCW04023K30JNED; Published: 2008-06-26; RoHS: Y; Signal Integrity: Resistor; Simulation: Resistor; Supplier 1: Digi-Key; Supplier 2: Mouser; Supplier 3: Farnell; Supplier Part Number 1: 541-3.3KJCT-ND; Supplier Part Number 2: 71-CRCW0402J-3.3K-E3; Supplier Part Number 3: 1514692; SymbolVersion: 2009-05-29; Technology: Thick Film; Value1: 5%; ValueDisplayed: 3.3k; Publisher: Texas Instruments
R128 = CRCW04026K80JNED: RES, 6.8 k, 5%, 0.063 W, 0402; Manufacturer: Vishay-Dale; CompType: Resistor; EIA_Code: E24; Power_W: 0.063; Res_ohm: 6800; TempCo: 200 ppm/C; Tolerance_%: 5; U/M: EA; Value2: 0.063W; Value_Code: 6k80; VDC_V: 50; Component Kind: Standard; ComponentLink1Description: Datasheet link; ComponentLink1URL: http://www.vishay.com/docs/20035/dcrcwe3.pdf; LatestRevisionDate: 2014-11-19; Library Name: Resistor_0402.IntLib; MountType: SMT; PackageReference: 0402; PartNumber: CRCW04026K80JNED; Published: 2008-06-26; RoHS: Y; Signal Integrity: Resistor; Simulation: Resistor; Supplier 1: Digi-Key; Supplier 2: Mouser; Supplier 3: Farnell; Supplier Part Number 1: 541-6.8KJCT-ND; Supplier Part Number 2: 71-CRCW0402J-6.8K-E3; Supplier Part Number 3: 1537431; SymbolVersion: 2009-05-29; Technology: Thick Film; Value1: 5%; ValueDisplayed: 6.8k; Publisher: Texas Instruments
T101 = BSS84W-7-F: MOSFET, P-CH, -50V, -0.13A, SOT-323; Alternate Manufacturer: None; Manufacturer: Diodes Inc.; ChType: P; IdsMax_A: -0.13; Rdson45_Ohm: 10; U/M: EA; Value1: 10 ohm; Value2: ? nC; VdsMax_V: -50; Vgs_V: -20; Component Kind: Standard; ComponentLink1Description: Datasheet link; ComponentLink1URL: http://www.diodes.com/datasheets/ds30205.pdf; CompType: MOSFET; LatestRevisionDate: 2014-05-29; LatestRevisionNote: Added parts.; Library Name: FETs.IntLib; MountType: SMT; PackageReference: SOT-323; PartNumber: BSS84W-7-F; Published: 2008-06-19; RoHS: Y; Simulation: DI_BSS84W; Supplier 1: Digi-Key; Supplier 2: Mouser; Supplier 3: Farnell; Supplier Part Number 1: BSS84W-FDICT-ND; Supplier Part Number 2: 621-BSS84W-F; Supplier Part Number 3: 1713836; SymbolVersion: 2008-07-09; Value: -50V; Publisher: Texas Instruments
T102 = BC850CLT1G, ON Semiconductor: Transistor, NPN, 45V, 0.1A, SOT-23; CompType: BJT; HfeMax: 800; IcRated_A: 0.1; NPN: 1; PNP: 0; TaMax_C: 150; TaMin_C: -55; U/M: EA; Value: 0.7V; Vceo_V: 45; Vcesat1_V: 0.7; Component Kind: Standard; ComponentLink1Description: Datasheet link; ComponentLink1URL: http://www.onsemi.com/pub_link/Collateral/BC846ALT1-D.PDF; LatestRevisionDate: 2014-05-16; Library Name: Bipolar_Transistor.IntLib; Manufacturer: ON Semiconductor; MountType: SMT; PackageReference: SOT-23; PartNumber: BC850CLT1G; Published: 2008-07-31; RoHS: Y; Supplier 1: Digi-Key; Supplier 2: Farnell; Supplier Part Number 1: BC850CLT1GOSCT-ND; Supplier Part Number 2: 1278476; SymbolVersion: 2008-07-31; Value1: 0.1A; Value2: 800; Publisher: Texas Instruments
TP1-TP8 = TESTPOINT: TEST POINT.  No entry in BOM.; CompType: Testpoint; LatestRevisionDate: 2014-12-30; LatestRevisionNote: Added Alternate PartNumber and Alternate Manufacturer parameters to appropriate components.; Library Name: MiscParts.IntLib; PackageReference: N/A; SymbolVersion: 2010-10-20; Publisher: Texas Instruments
U101 = MSP430F5528IRGCR: 25 MHz Mixed Signal Microcontroller with 128 KB Flash, 8192 B SRAM and 47 GPIOs, -40 to 85 degC, 64-pin QFN (RGC), Green (RoHS & no Sb/Br); Manufacturer: Texas Instruments; ADC: 12-bit SAR; ADC Channels: 16; Brown Out Reset: Yes; DMA: 3; Flash(KB): 128; Frequency(MHz): 25; GPIO: 47; Multiplier: 32x32; SRAM(B): 8192; SubFamily: 5 Series; Timers - 16-bit: 4; USB: 1; USCI_A (UART/LIN/IrDA/SPI): 2; Comparators: Yes; Component Kind: Standard; ComponentLink1Description: Manufacturer URL; ComponentLink1URL: http://www.ti.com/; ComponentLink2Description: Datasheet; ComponentLink2URL: http://www.ti.com/general/docs/lit/getliterature.tsp?genericPartNumber=MSP430F5528&fileType=pdf; ComponentLink3Description: Package Specification; ComponentLink3URL: http://www.ti.com/lit/ds/symlink/ucd3138.pdf; DatasheetVersion: SLAS590I; Eco-Plan: Green (RoHS & no Sb/Br); Library Name: TI MCU MSP430.IntLib; Mounting Technology: Surface Mount; PackageDescription: 64-Pin Plastic Flat Pack, Body 9 x 9 mm, Pitch 0.5 mm; PackageReference: RGC0064B; PackageVersion: 4204106/F; PartNumber: MSP430F5528IRGCR; Real-Time Clock: Yes; Supplier 1: Digi-Key; Supplier 2: Digi-Key; Supplier 3: Digi-Key; Supplier 4: Newark; Supplier 5: Mouser; Supplier Part Number 1: 296-27305-2-ND; Supplier Part Number 2: 296-27305-1-ND; Supplier Part Number 3: 296-27305-6-ND; Supplier Part Number 4: 74R6473; Supplier Part Number 5: 595-MSP430F5527IPNR; SVS: Yes; Temp Sensor: Yes; USCI_B (I2C & SPI): 2; Watchdog: Yes
U102 = MSP430G2452IRSA16R: 16 MHz Mixed Signal Microcontroller with 8 KB Flash, 256 B SRAM and 16 GPIOs, 16-pin QFN (RSA), Green (RoHS & no Sb/Br); Alternate Manufacturer: Texas Instruments; Alternate PartNumber: Equivalent; ADC: 10-bit SAR; ADC Channels: 8; Brown Out Reset: Yes; Frequency(MHz): 16; Pin/Package: 14TSSOP, 16QFN, 20PDIP, 20TSSOP; SRAM(B): 256; SubFamily: Value Line; Timers - 16-bit: 1; USI: (I2C/SPI); Cap touch I/O: Yes; Code_JEDEC: MO-220; Comparators: Yes; Component Kind: Standard; ComponentLink1Description: Manufacturer URL; ComponentLink1URL: http://www.ti.com/; ComponentLink2Description: Datasheet; ComponentLink2URL: http://www.ti.com/general/docs/lit/getliterature.tsp?genericPartNumber=MSP430G2452&fileType=pdf; DatasheetVersion: SLAS722E; Eco-Plan: Green (RoHS & no Sb/Br); Flash(KB): 8; GPIO: 16; Library Name: TI MCU MSP430.IntLib; Manufacturer: Texas Instruments; MountType: SMT; PackageDescription: 16-Pin Plastic Flat Pack, Body 4.00 x 4.00 mm, Pitch 0.65 mm; PackageReference: RSA0016B; PackageVersion: 4205141/D, 06/2011; PartNumber: MSP430G2452IRSA16R; Supplier 1: Digi-Key; Temp Sensor: Yes; Watchdog: Yes
U103 = TS5A21366RSE: 0.75-Ω DUAL SPST ANALOG SWITCH WITH 1.8-V COMPATIBLE INPUT LOGIC, RSE0008A; Alternate Manufacturer: Texas Instruments; CompType: IC; SymbolCreator: a0272918; U/M: EA; Component Kind: Standard; ComponentLink1Description: Datasheet Link; ComponentLink1URL: http://www.ti.com/lit/ds/symlink/ts5a21366.pdf; LatestRevisionDate: 2014-12-08; Manufacturer: Texas Instruments; MountType: SMT; PackageReference: RES008A; PartNumber: TS5A21366RSE; RoHS: Y; Supplier 1: Digi-Key; SymbolVersion: 2014-12-09; Library Name: Captivate Library.IntLib; Publisher: Texas Instruments
